# Altium schematic sheet sensie_v_0_0.SchDoc(sensie_v_0_0)
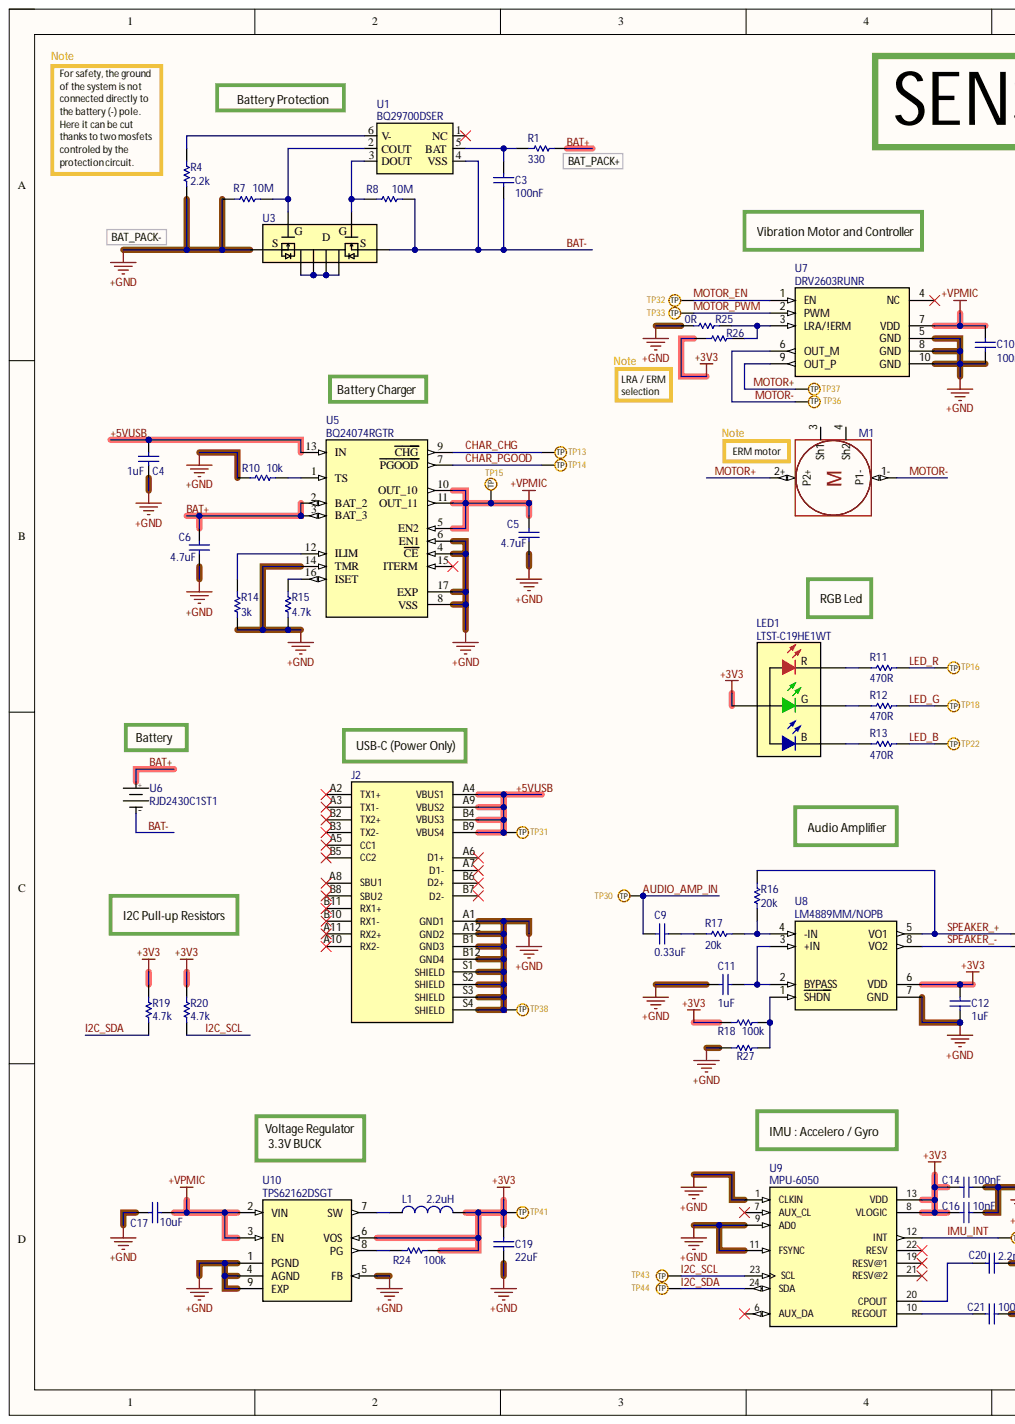
[diagram p0: sensie_v_0_0.SchDoc, left]
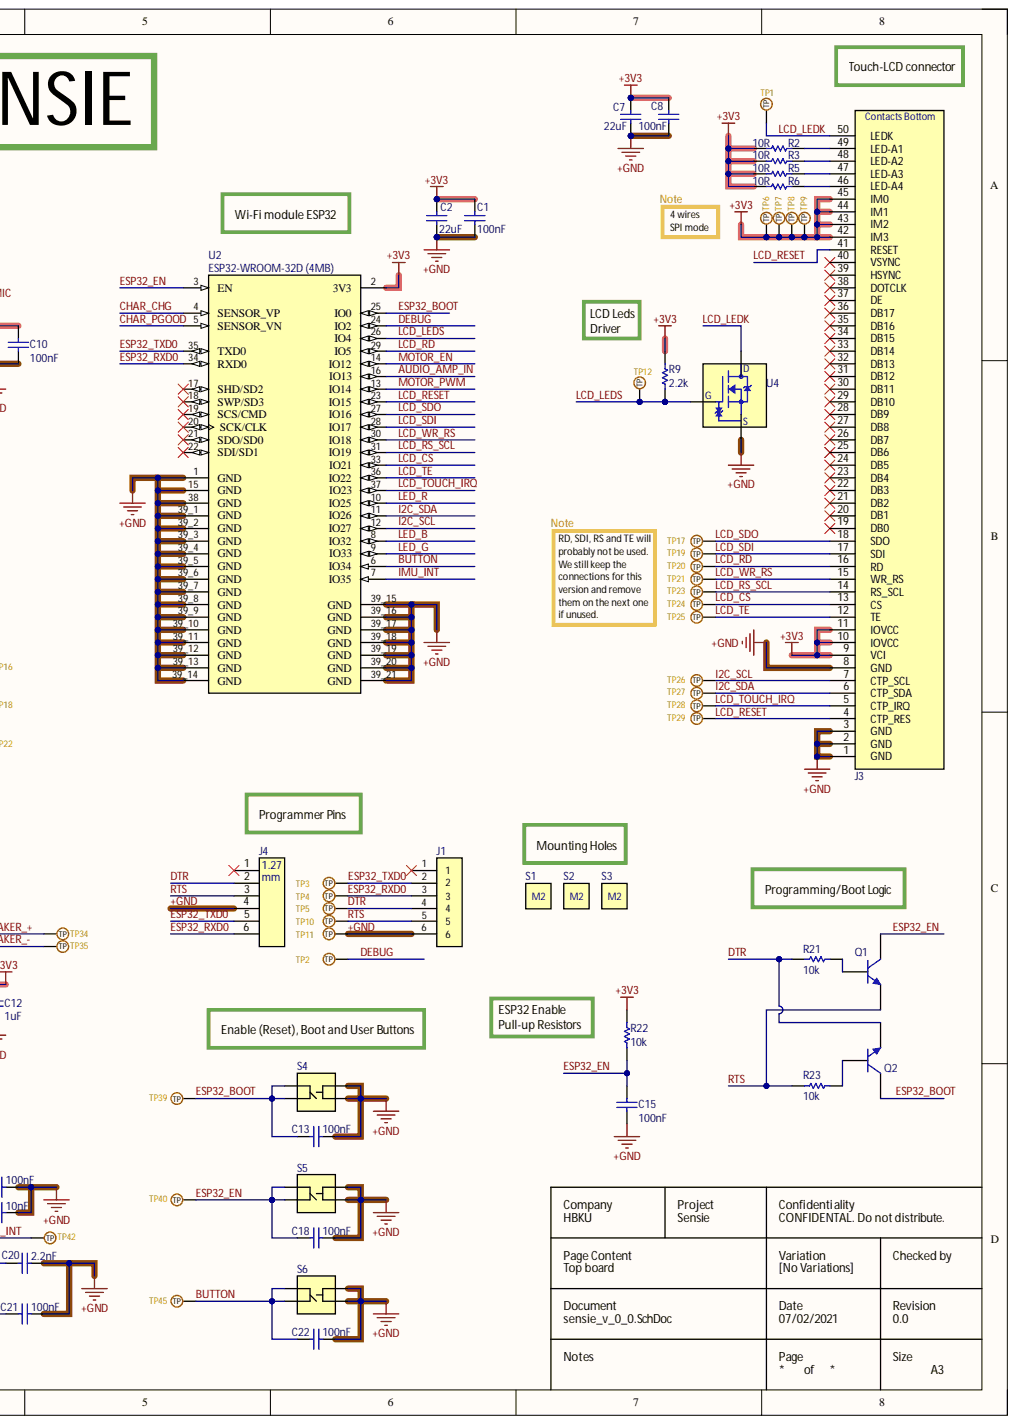
[diagram p1: sensie_v_0_0.SchDoc, right]

## Parts
C1  value 100nF  [C0402]  (hidden comment: Multilayer Ceramic Capacitor)
C2  value 22uF  [C0603]  (hidden comment: Multilayer Ceramic Capacitor)
C3  value 100nF  [C0402]  (hidden comment: Multilayer Ceramic Capacitor)
C4  value 1uF  [C0402]  (hidden comment: Multilayer Ceramic Capacitor)
C5  value 4.7uF  [C0402]  (hidden comment: Multilayer Ceramic Capacitor)
C6  value 4.7uF  [C0402]  (hidden comment: Multilayer Ceramic Capacitor)
C7  value 22uF  [C0603]  (hidden comment: Multilayer Ceramic Capacitor)
C8  value 100nF  [C0402]  (hidden comment: Multilayer Ceramic Capacitor)
C9  value 0.33uF  [C0402]  (hidden comment: Multilayer Ceramic Capacitor)
C10  value 100nF  [C0402]  (hidden comment: Multilayer Ceramic Capacitor)
C11  value 1uF  [C0402]  (hidden comment: Multilayer Ceramic Capacitor)
C12  value 1uF  [C0402]  (hidden comment: Multilayer Ceramic Capacitor)
C13  value 100nF  [C0402]  (hidden comment: Multilayer Ceramic Capacitor)
C14  value 100nF  [C0402]  (hidden comment: Multilayer Ceramic Capacitor)
C15  value 100nF  [C0402]  (hidden comment: Multilayer Ceramic Capacitor)
C16  value 10nF  [C0402]  (hidden comment: Multilayer Ceramic Capacitor)
C17  value 10uF  [C0402]  (hidden comment: Multilayer Ceramic Capacitor)
C18  value 100nF  [C0402]  (hidden comment: Multilayer Ceramic Capacitor)
C19  value 22uF  [C0603]  (hidden comment: Multilayer Ceramic Capacitor)
C20  value 2.2nF  [C0402]  (hidden comment: Multilayer Ceramic Capacitor)
C21  value 100nF  [C0402]  (hidden comment: Multilayer Ceramic Capacitor)
C22  value 100nF  [C0402]  (hidden comment: Multilayer Ceramic Capacitor)
J1  Plug of Nails 6 pins  [PLUG-OF-NAILS-6-PIN]  (value not drawn)
J2  1054500101  [USB-C-1054500101]  (value not drawn)
J3  FPC-50-screen-connector-bottom-62684-501100ALF  [FPC-50-62684-501100ALF]  (value not drawn)
J4  FTS-103-01-F-DV-TR  [CON_6_2_1.27_FTS_103_01]  (value not drawn)
L1  value 2.2uH  [L0603]  (hidden comment: IND 2.2uH 0603)
LED1  Manufacturer Part Number: LTST-C19HE1WT  [LTST-C19HE1WT-4_V]  (hidden comment: rgb_LTST-C19HE1WT)
M1  MOTOR  [MOTOR]
Q1  MMBT2222ALT1G  [ONSC-SOT-23-3-318-08_V]  (value not drawn)
Q2  MMBT2222ALT1G  [ONSC-SOT-23-3-318-08_V]  (value not drawn)
R1  value 330  [R0402]  (hidden comment: Thick Film)
R2  value 10R  [R0402]  (hidden comment: Thick Film)
R3  value 10R  [R0402]  (hidden comment: Thick Film)
R4  value 2.2k  [R0402]  (hidden comment: Thick Film)
R5  value 10R  [R0402]  (hidden comment: Thick Film)
R6  value 10R  [R0402]  (hidden comment: Thick Film)
R7  value 10M  [R0402]  (hidden comment: Thick Film)
R8  value 10M  [R0402]  (hidden comment: Thick Film)
R9  value 2.2k  [R0402]  (hidden comment: Thick Film)
R10  value 10k  [R0402]  (hidden comment: Thick Film)
R11  value 470R  [R0402]  (hidden comment: Thick Film)
R12  value 470R  [R0402]  (hidden comment: Thick Film)
R13  value 470R  [R0402]  (hidden comment: Thick Film)
R14  value 3k  [R0402]  (hidden comment: Thick Film)
R15  value 4.7k  [R0402]  (hidden comment: Thick Film)
R16  value 20k  [R0402]  (hidden comment: Thick Film)
R17  value 20k  [R0402]  (hidden comment: Thick Film)
R18  value 100k  [R0402]  (hidden comment: Thick Film)
R19  value 4.7k  [R0402]  (hidden comment: Thick Film)
R20  value 4.7k  [R0402]  (hidden comment: Thick Film)
R21  value 10k  [R0402]  (hidden comment: Thick Film)
R22  value 10k  [R0402]  (hidden comment: Thick Film)
R23  value 10k  [R0402]  (hidden comment: Thick Film)
R24  value 100k  [R0402]  (hidden comment: Thick Film)
R25  value 0R  [R0402]  (hidden comment: Thick Film)
R26  Thick Film  [R0402_DNI]  (value not drawn)
R27  Thick Film  [R0402_DNI]  (value not drawn)
S1  hole_M2  [M2_no_screw]  (value not drawn)
S2  hole_M2  [M2_no_screw]  (value not drawn)
S3  hole_M2  [M2_no_screw]  (value not drawn)
S4  TL1014BF160QG  [BUTTON_TL1014BF160QG]  (value not drawn)
S5  TL1014BF160QG  [BUTTON_TL1014BF160QG]  (value not drawn)
S6  TL1014BF160QG  [BUTTON_TL1014BF160QG]  (value not drawn)
TP1  TP_1mm  [TP_1mm]  (value not drawn)
TP2  TP_2mm  [TP_2mm]  (value not drawn)
TP3  TP_2mm  [TP_2mm]  (value not drawn)
TP4  TP_2mm  [TP_2mm]  (value not drawn)
TP5  TP_2mm  [TP_2mm]  (value not drawn)
TP6  TP_1mm  [TP_1mm]  (value not drawn)
TP7  TP_1mm  [TP_1mm]  (value not drawn)
TP8  TP_1mm  [TP_1mm]  (value not drawn)
TP9  TP_1mm  [TP_1mm]  (value not drawn)
TP10  TP_2mm  [TP_2mm]  (value not drawn)
TP11  TP_2mm  [TP_2mm]  (value not drawn)
TP12  TP_1mm  [TP_1mm]  (value not drawn)
TP13  TP_1mm  [TP_1mm]  (value not drawn)
TP14  TP_1mm  [TP_1mm]  (value not drawn)
TP15  TP_1mm  [TP_1mm]  (value not drawn)
TP16  TP_1mm  [TP_1mm]  (value not drawn)
TP17  TP_1mm  [TP_1mm]  (value not drawn)
TP18  TP_1mm  [TP_1mm]  (value not drawn)
TP19  TP_1mm  [TP_1mm]  (value not drawn)
TP20  TP_1mm  [TP_1mm]  (value not drawn)
TP21  TP_1mm  [TP_1mm]  (value not drawn)
TP22  TP_1mm  [TP_1mm]  (value not drawn)
TP23  TP_1mm  [TP_1mm]  (value not drawn)
TP24  TP_1mm  [TP_1mm]  (value not drawn)
TP25  TP_1mm  [TP_1mm]  (value not drawn)
TP26  TP_1mm  [TP_1mm]  (value not drawn)
TP27  TP_1mm  [TP_1mm]  (value not drawn)
TP28  TP_1mm  [TP_1mm]  (value not drawn)
TP29  TP_1mm  [TP_1mm]  (value not drawn)
TP30  TP_1mm  [TP_1mm]  (value not drawn)
TP31  TP_1mm  [TP_1mm]  (value not drawn)
TP32  TP_1mm  [TP_1mm]  (value not drawn)
TP33  TP_1mm  [TP_1mm]  (value not drawn)
TP34  TP_2mm  [TP_2mm]  (value not drawn)
TP35  TP_2mm  [TP_2mm]  (value not drawn)
TP36  TP_2mm  [TP_2mm]  (value not drawn)
TP37  TP_2mm  [TP_2mm]  (value not drawn)
TP38  TP_1mm  [TP_1mm]  (value not drawn)
TP39  TP_1mm  [TP_1mm]  (value not drawn)
TP40  TP_1mm  [TP_1mm]  (value not drawn)
TP41  TP_1mm  [TP_1mm]  (value not drawn)
TP42  TP_1mm  [TP_1mm]  (value not drawn)
TP43  TP_1mm  [TP_1mm]  (value not drawn)
TP44  TP_1mm  [TP_1mm]  (value not drawn)
TP45  TP_1mm  [TP_1mm]  (value not drawn)
U1  BQ29700DSER  [BATT_PROTEC_BQ29700DSER]
U2  ESP32-WROOM-32D  [ESP32-WROOM-32D (4MB)]
U3  ECH8693R-TL-W  [MOSFET_2CHAN_ECH8693R-TL-W]  (value not drawn)
U4  DMG1012UW-7  [MOSFET-DMG1012UW-SOT323]  (value not drawn)
U5  BQ24074RGTR  [BATT_CHARG_BQ24074RGTR]
U6  RJD2430C1ST1  [COIN_BAT_110_MAH_RJD2430C1ST1]
U7  DRV2603RUNR  [MOT_DRV_DRV2603RUNR]
U8  LM4889  Manufacturer Part Number: LM4889MM/NOPB  [Amp_LM4889MM]
U9  MPU-6050  [MPU6050-ACCELERO-GYRO]
U10  TPS62162DSGT  [REG_BUCK_TPS62162DSGT]

## Nets
+3V3: C1.2 C2.2 C7.2 C8.2 C12.2 C14.1 C16.1 C19.2 J3.9(VCI) J3.10(IOVCC) J3.11(IOVCC) J3.42(IM3) J3.43(IM2) J3.44(IM1) J3.45(IM0) L1.2 LED1.4 R2.1 R3.1 R5.1 R6.1 R9.2 R18.1 R19.2 R20.2 R22.2 R24.2 R26.1 TP6.1 TP7.1 TP8.1 TP9.1 TP41.1 U2.2(3V3) U8.6(VDD) U9.8(VLOGIC) U9.13(VDD) U10.6(VOS)
+VPMIC: C5.2 C10.2 C17.2 TP15.1 U5.5(EN2) U5.10(OUT_10) U5.11(OUT_11) U7.7(VDD) U10.2(VIN) U10.3(EN)
+5VUSB: C4.2 J2.A4(VBUS1) J2.A9(VBUS2) J2.B4(VBUS3) J2.B9(VBUS4) TP31.1 U5.13(IN)
+GND: C1.1 C2.1 C4.1 C5.1 C6.1 C7.1 C8.1 C10.1 C11.2 C12.1 C13.2 C14.2 C15.1 C16.2 C17.1 C18.2 C19.1 C20.2 C21.2 C22.2 J1.6 J2.A1(GND1) J2.A12(GND2) J2.B1(GND3) J2.B12(GND4) J2.S1(SHIELD) J2.S2(SHIELD) J2.S3(SHIELD) J2.S4(SHIELD) J3.1(GND) J3.2(GND) J3.3(GND) J3.8(GND) J4.4 R4.1 R7.2 R10.2 R14.1 R15.1 R25.1 R27.1 S4.1 S4.3 S5.1 S5.3 S6.1 S6.3 TP11.1 TP38.1 U2.1(GND) U2.15(GND) U2.38(GND) U2.39_1(GND) U2.39_2(GND) U2.39_3(GND) U2.39_4(GND) U2.39_5(GND) U2.39_6(GND) U2.39_7(GND) U2.39_8(GND) U2.39_9(GND) U2.39_10(GND) U2.39_11(GND) U2.39_12(GND) U2.39_13(GND) U2.39_14(GND) U2.39_15(GND) U2.39_16(GND) U2.39_17(GND) U2.39_18(GND) U2.39_19(GND) U2.39_20(GND) U2.39_21(GND) U3.1 U4.3 U5.4(CE) U5.6(EN1) U5.8(VSS) U5.14(TMR) U5.17(EXP) U7.5(GND) U7.8(GND) U7.10(GND) U8.7(GND) U9.1(CLKIN) U9.9(AD0) U9.11(FSYNC) U10.1(PGND) U10.4(AGND) U10.5(FB) U10.9(EXP)
AUDIO_AMP_IN: C9.1 TP30.1 U2.16(IO13)
BAT+: C6.2 R1.2 U5.2(BAT_2) U5.3(BAT_3) U6.1
BAT-: C3.1 R8.1 U1.4(VSS) U3.3 U6.2
BUTTON: C22.1 S6.2 S6.4 TP45.1 U2.6(IO34)
CHAR_CHG: TP13.1 U2.4(SENSOR_VP) U5.9(CHG)
CHAR_PGOOD: TP14.1 U2.5(SENSOR_VN) U5.7(PGOOD)
DEBUG: TP2.1 U2.24(IO2)
DTR: J1.4 J4.2 Q2.2 R21.1 TP5.1
ESP32_BOOT: C13.1 Q2.3 S4.2 S4.4 TP39.1 U2.25(IO0)
ESP32_EN: C15.2 C18.1 Q1.3 R22.1 S5.2 S5.4 TP40.1 U2.3(EN)
ESP32_RXD0: J1.3 J4.6 TP4.1 U2.34(RXD0)
ESP32_TXD0: J1.2 J4.5 TP3.1 U2.35(TXD0)
I2C_SCL: J3.7(CTP_SCL) R20.1 TP26.1 TP43.1 U2.12(IO27) U9.23(SCL)
I2C_SDA: J3.6(CTP_SDA) R19.1 TP27.1 TP44.1 U2.11(IO26) U9.24(SDA)
IMU_INT: TP42.1 U2.7(IO35) U9.12(INT)
LCD_CS: J3.13(CS) TP24.1 U2.33(IO21)
LCD_LEDK: J3.50(LEDK) TP1.1 U4.1
LCD_LEDS: R9.1 TP12.1 U2.26(IO4) U4.2
LCD_RD: J3.16(RD) TP20.1 U2.29(IO5)
LCD_RESET: J3.4(CTP_RES) J3.41(RESET) TP29.1 U2.23(IO15)
LCD_RS_SCL: J3.14(RS_SCL) TP23.1 U2.31(IO19)
LCD_SDI: J3.17(SDI) TP19.1 U2.28(IO17)
LCD_SDO: J3.18(SDO) TP17.1 U2.27(IO16)
LCD_TE: J3.12(TE) TP25.1 U2.36(IO22)
LCD_TOUCH_IRQ: J3.5(CTP_IRQ) TP28.1 U2.37(IO23)
LCD_WR_RS: J3.15(WR_RS) TP21.1 U2.30(IO18)
LED_B: R13.2 TP22.1 U2.8(IO32)
LED_G: R12.2 TP18.1 U2.9(IO33)
LED_R: R11.2 TP16.1 U2.10(IO25)
MOTOR+: M1.2+ TP37.1 U7.9(OUT_P)
MOTOR-: M1.1-(M) TP36.1 U7.6(OUT_M)
MOTOR_EN: TP32.1 U2.14(IO12) U7.1(EN)
MOTOR_PWM: TP33.1 U2.13(IO14) U7.2(PWM)
RTS: J1.5 J4.3 Q1.2 R23.1 TP10.1
SPEAKER_+: R16.2 TP34.1 U8.5(VO1)
SPEAKER_-: TP35.1 U8.8(VO2)
NetC3_2: C3.2 R1.1 U1.5(BAT)
NetC9_2: C9.2 R17.1
NetC11_1: C11.1 U8.2(BYPASS) U8.3(+IN)
NetC20_1: C20.1 U9.20(CPOUT)
NetC21_1: C21.1 U9.10(REGOUT)
NetJ3_46: J3.46(LED-A4) R6.2
NetJ3_47: J3.47(LED-A3) R5.2
NetJ3_48: J3.48(LED-A2) R3.2
NetJ3_49: J3.49(LED-A1) R2.2
NetL1_1: L1.1 U10.7(SW)
NetLED1_1: LED1.1 R11.1
NetLED1_2: LED1.2 R12.1
NetLED1_3: LED1.3 R13.1
NetQ1_1: Q1.1 R21.2
NetQ2_1: Q2.1 R23.2
NetR4_2: R4.2 U1.6(V-)
NetR7_1: R7.1 U1.2(COUT) U3.2
NetR8_2: R8.2 U1.3(DOUT) U3.4
NetR10_1: R10.1 U5.1(TS)
NetR14_2: R14.2 U5.12(ILIM)
NetR15_2: R15.2 U5.16(ISET)
NetR16_1: R16.1 R17.2 U8.4(-IN)
NetR18_2: R18.2 R27.2 U8.1(SHDN)
NetR24_1: R24.1 U10.8(PG)
NetR25_2: R25.2 R26.2 U7.3(LRA/!ERM)
NetU3_5: U3.5 U3.6(D) U3.7 U3.8(G)

Not connected: J1 1; J2 A2(TX1+) A3(TX1-) A5(CC1) A6(D1+) A7(D1-) A8(SBU1) A10(RX2-) A11(RX2+) B2(TX2+) B3(TX2-) B5(CC2) B6(D2+) B7(D2-) B8(SBU2) B10(RX1-) B11(RX1+); J3 19(DB0) 20(DB1) 21(DB2) 22(DB3) 23(DB4) 24(DB5) 25(DB6) 26(DB7) 27(DB8) 28(DB9) 29(DB10) 30(DB11) 31(DB12) 32(DB13) 33(DB14) 34(DB15) 35(DB16) 36(DB17) 37(DE) 38(DOTCLK) 39(HSYNC) 40(VSYNC); J4 1(1.27); M1 3(Sh1) 4(Sh2); U1 1(NC); U2 17(SHD/SD2) 18(SWP/SD3) 19(SCS/CMD) 20(SCK/CLK) 21(SDO/SD0) 22(SDI/SD1); U5 15(ITERM); U7 4(NC); U9 6(AUX_DA) 7(AUX_CL) 19(RESV@1) 21(RESV@2) 22(RESV)

## Library data of the parts
C1 C3 C8 C10 C13-C15 C18 C21 C22 = CAP 100nF 0402: CAP CER 0.1UF 16V X7R 0402; Manufacturer: Murata Electronics; Manufacturer Part Number: GRM155R71C104KA88J; Component Kind: Standard; Library Name: rcl.IntLib; Link: https://www.digikey.com/product-detail/en/murata-electronics/GRM155R71C104KA88J/490-6328-1-ND/3845525; Package: 0402; Supplier: Digikey; Supplier Part Number: 490-6328-1-ND; Voltage Rating: 16V
C2 C7 C19 = CAP 22uF 0603: CAP CER 22UF 6.3V X5R 0603; Manufacturer: Murata Electronics; Manufacturer Part Number: GRM188R60J226MEA0D; Component Kind: Standard; Library Name: rcl.IntLib; Link: https://www.digikey.com/product-detail/en/murata-electronics/GRM188R60J226MEA0D/490-7611-1-ND/4280544; Package: 0603; Supplier: Digikey; Supplier Part Number: 490-7611-1-ND; Voltage Rating: 6.3V
C4 C11 C12 = CAP 1uF 0402: CAP CER 1UF 10V X6S 0402; Manufacturer: Murata Electronics; Manufacturer Part Number: GRM155C81A105KA12D; Component Kind: Standard; Library Name: rcl.IntLib; Link: https://www.digikey.com/product-detail/en/murata-electronics/GRM155C81A105KA12D/490-12699-1-ND/5797668; Package: 0402; Supplier: Digikey; Supplier Part Number: 490-12699-1-ND; Voltage Rating: 10V
C5 C6 = CAP 4.7uF 0402: CAP CER 4.7UF 6.3V X5R 0402; Manufacturer: Murata Electronics; Manufacturer Part Number: GRM155R60J475ME47D; Component Kind: Standard; Library Name: rcl.IntLib; Link: https://www.digikey.com/product-detail/en/murata-electronics/GRM155R60J475ME47D/490-5915-1-ND/3719860; Package: 0402; Supplier: Digikey; Supplier Part Number: 490-5915-1-ND; Voltage Rating: 6.3V
C9 = CAP 0.33uF 0402: CAP CER 0.33UF 10V X6S 0402; Manufacturer: Murata Electronics; Manufacturer Part Number: GRM155R61A334KE15D; Component Kind: Standard; Library Name: rcl.IntLib; Link: https://www.digikey.com/en/products/detail/murata-electronics/GRM155R61A334KE15D/702527; Package: 0402; Supplier: Digikey; Supplier Part Number: 490-3263-1-ND; Voltage Rating: 10V
C16 = CAP 10nF 0402: CAP CER 10nF 16V X7R 0402; Manufacturer: Murata Electronics; Manufacturer Part Number: GCM155R71H103KA55D; Component Kind: Standard; Library Name: rcl.IntLib; Link: https://www.digikey.com/en/products/detail/murata-electronics/GCM155R71H103KA55D/1641636; Package: 0402; Supplier: Digikey; Supplier Part Number: 490-4762-1-ND; Voltage Rating: 16V
C17 = CAP 10uF 0402: CAP CER 10UF 4V X5R 0402; Manufacturer: Murata Electronics; Manufacturer Part Number: GRM155R60G106ME44D; Component Kind: Standard; Library Name: rcl.IntLib; Link: https://www.digikey.com/product-detail/en/murata-electronics/GRM155R60G106ME44D/490-10693-1-ND/5251412; Package: 0402; Supplier: Digikey; Supplier Part Number: 490-10693-1-ND; Voltage Rating: 4V
C20 = CAP 2.2nF 0402: CAP CER 2.2nF 16V X7R 0402; Manufacturer: Murata Electronics; Manufacturer Part Number: GCM155R72A222KA37D; Component Kind: Standard; Library Name: rcl.IntLib; Link: https://www.digikey.com/en/products/detail/murata-electronics/GCM155R72A222KA37D/1765159; Package: 0402; Supplier: Digikey; Supplier Part Number: 490-4911-1-ND; Voltage Rating: 16V
J1 = Plug of Nails 6 pins: CBL PLUG-OF-NAILS 6-PIN with NO ATTACHMENT holes (contrary to the digikey link); Manufacturer: Tag-Connect LLC; Manufacturer Part Number: TC2030-CTX; Component Kind: Standard; Library Name: connectors.IntLib; Link: https://www.digikey.co.uk/product-detail/en/tag-connect-llc/TC2030-CTX/TC2030-CTX-ND/5023324; Price 1 Unit USD: 0; Price 1000+ Units USD: 0; Supplier: Digikey; Supplier Part Number: TC2030-CTX-ND
J2 = 1054500101: USB-C CONN RCP USB3.1 TYPEC 24P SMD RA; Manufacturer: Molex; zz Current Rating Per Pin: 0.25A, 1A, 5A; Component Kind: Standard; Library Name: connectors.IntLib; Link: https://www.digikey.com/en/products/detail/molex/1054500101/5843890; Manufacturer Part Number: 1054500101; Price 1 Unit USD: 1.10; Price 1000+ Units USD: 2.19; Supplier: Digikey; Supplier Part Number: WM12856CT-ND
J3 = FPC-50-screen-connector-bottom-62684-501100ALF: FPC CONN BOTTOM 50POS 0.50MM R/A; Manufacturer: Amphenol ICC (FCI); zz Current Rating Per Pin: 0.5A; Component Kind: Standard; Library Name: connectors.IntLib; Link: https://www.digikey.com/en/products/detail/amphenol-icc-fci/62684-501100ALF/1001511; Manufacturer Part Number: 62684-501100ALF; Price 1 Unit USD: 0.88; Price 1000+ Units USD: 0.48; Supplier: Digikey; Supplier Part Number: 609-1197-1-ND
J4 = FTS-103-01-F-DV-TR: CONN HEADER SMD 6POS 1.27MM; Manufacturer: Samtec Inc.; zz Current Rating Per Pin: 3.4A; Component Kind: Standard; Library Name: connectors.IntLib; Link: https://www.digikey.com/en/products/detail/samtec-inc/FTS-103-01-F-DV-TR/2649936; Manufacturer Part Number: FTS-103-01-F-DV-TR; Price 1 Unit USD: 1.39; Price 1000+ Units USD: 0.78; Supplier: Digikey; Supplier Part Number: SAM14930CT-ND
L1 = IND 2.2uH 0603: 2.2UH20% 1.05A(MAX) / 1.15A(TYP.; Manufacturer: Murata Electronics; Manufacturer Part Number: LQM18PN2R2MGHD; Tolerance: 20%; Component Kind: Standard; Library Name: rcl.IntLib; Link: https://www.digikey.co.uk/product-detail/en/murata-electronics/LQM18PN2R2MGHD/490-14478-6-ND/6607082; Package: 0603; Supplier: Digikey; Supplier Part Number: 490-14478-6-ND
LED1 = rgb_LTST-C19HE1WT: LED RGB DIFFUSED CHIP SMD  LED Tri-Color Blue/Green/Red, 5 V, -20 to 80 degC, 4-Pin SMD, RoHS, Tape and Reel; Manufacturer: Lite-On Inc.; zz Package: 0606; zz Power Supply Rating: 2.1V Red, 3.35V Green, 3.35V Blue; Component Kind: Standard; Library Name: chips.IntLib; Link: https://www.digikey.com/product-detail/en/lite-on-inc/LTST-C19HE1WT/160-2162-1-ND/4866310; Price 1 Unit USD: 0.56; Price 1000+ Units USD: 0.13; Supplier: Digikey; Supplier Part Number: 160-2162-1-ND
M1 = MOTOR: VIBRATION MOTOR CYL 3V SMD; Manufacturer: Jinlong Machinery & Electronics, Inc.; Manufacturer Part Number: Z4FC1B1301781; Component Kind: Standard; Link: https://www.digikey.com/product-detail/en/jinlong-machinery-electronics-inc/Z4FC1B1301781/1670-1011-1-ND/6009936; Price 1 Unit USD: 3.49; Price 1000+ Units USD: 2.08; Supplier: Digikey; Supplier Part Number: 1670-1011-1-ND; Library Name: miscellaneaous.IntLib
Q1 Q2 = MMBT2222ALT1G: TRANS NPN 40V 0.6A SOT23   General Purpose Transistor, NPN Silicon, 3-Pin SOT-23, Pb-Free, Tape and Reel; Manufacturer: ON Semiconductor; Component Kind: Standard; ComponentLink1Description: Manufacturer URL; ComponentLink1URL: http://www.onsemi.com/; ComponentLink2Description: Datasheet; ComponentLink2URL: http://www.onsemi.cn/pub_link/Collateral/MMBT2222LT1-D.PDF; ComponentLink3Description: Package Specification; ComponentLink3URL: http://www.onsemi.cn/pub_link/Collateral/318-08.PDF; Library Name: chips.IntLib; Link: https://www.digikey.co.uk/product-detail/en/on-semiconductor/MMBT2222ALT1G/MMBT2222ALT1GOSCT-ND/1139806; Manufacturer Part Number: MMBT2222ALT1G; Price 1 Unit USD: 0.15; Price 1000+ Units USD: 0.02; Supplier: Digikey; Supplier Part Number: MMBT2222ALT1GOSCT-ND
R1 = RES 330R 0402: RES SMD 330 OHM 1% 1/16W 0402; Manufacturer: Yageo; Manufacturer Part Number: RC0402FR-07330RL; Component Kind: Standard; Library Name: rcl.IntLib; Link: https://www.digikey.co.uk/product-detail/en/yageo/RC0402FR-07330RL/311-330LRCT-ND/729541; Package: 0402; Power: 1/16W; Supplier: Digikey; Supplier Part Number: 311-330LRCT-ND; Tolerance: 1%
R2 R3 R5 R6 = RES 10R 0402: RES SMD 10 OHM 1% 1/16W 0402; Manufacturer: Yageo; Manufacturer Part Number: RC0402FR-0710RL; Component Kind: Standard; Library Name: rcl.IntLib; Link: https://www.digikey.co.uk/product-detail/en/yageo/RC0402FR-0710RL/311-10-0LRCT-ND/729471; Package: 0402; Power: 1/16W; Supplier: Digikey; Supplier Part Number: 311-10.0LRCT-ND; Tolerance: 1%
R4 R9 = RES 2.2k 0402: RES SMD 2.2K OHM 1% 1/16W 0402; Manufacturer: Yageo; Manufacturer Part Number: RC0402FR-072K2L; Component Kind: Standard; Library Name: rcl.IntLib; Link: https://www.digikey.co.uk/product-detail/en/yageo/RC0402FR-072K2L/311-2-20KLRCT-ND/729500; Package: 0402; Power: 1/16W; Supplier: Digikey; Supplier Part Number: 311-2.20KLRCT-ND; Tolerance: 1%
R7 R8 = RES 10M 0402: RES SMD 10 MOHM 1% 1/16W 0402; Manufacturer: Yageo; Manufacturer Part Number: RC0402FR-0710ML; Component Kind: Standard; Library Name: rcl.IntLib; Link: https://www.digikey.co.uk/product-detail/en/yageo/RC0402FR-0710ML/311-10-0MLRCT-ND/2827890; Package: 0402; Power: 1/16W; Supplier: Digikey; Supplier Part Number: 311-10.0MLRCT-ND; Tolerance: 1%
R10 R21-R23 = RES 10k 0402: RES SMD 10K OHM 1% 1/16W 0402; Manufacturer: Yageo; Manufacturer Part Number: RC0402FR-0710KL; Component Kind: Standard; Library Name: rcl.IntLib; Link: https://www.digikey.com/product-detail/en/yageo/RC0402FR-0710KL/311-10-0KLRCT-ND/729470; Package: 0402; Power: 1/16W; Supplier: Digikey; Supplier Part Number: 311-10.0KLRCT-ND; Tolerance: 1%
R11-R13 = RES 470R 0402: RES SMD 470 OHM 1% 1/16W 0402; Manufacturer: Yageo; Manufacturer Part Number: RC0402FR-07470RL; Component Kind: Standard; Library Name: rcl.IntLib; Link: https://www.digikey.com/product-detail/en/yageo/RC0402FR-07470RL/311-470LRCT-ND/729566; Package: 0402; Power: 1/16W; Supplier: Digikey; Supplier Part Number: 311-470LRCT-ND; Tolerance: 1%
R14 = RES 3k 0402: RES SMD 10K OHM 1% 1/16W 0402; Manufacturer: Yageo; Manufacturer Part Number: RC0402FR-073KL; Component Kind: Standard; Library Name: rcl.IntLib; Link: https://www.digikey.co.uk/product-detail/en/yageo/RC0402FR-073KL/311-3KLRCT-ND/2827904; Package: 0402; Power: 1/16W; Supplier: Digikey; Supplier Part Number: 311-3KLRCT-ND; Tolerance: 1%
R15 R19 R20 = RES 4.7k 0402: RES SMD 4.7K OHM 1% 1/16W 0402; Manufacturer: Yageo; Manufacturer Part Number: RT0402FRE074K7L; Component Kind: Standard; Library Name: rcl.IntLib; Link: https://www.digikey.co.uk/product-detail/en/yageo/RT0402FRE074K7L/YAG3347CT-ND/5418052; Power: 1/16W; Supplier: Digikey; Supplier Part Number: YAG3347CT-ND; Tolerance: 1%
R16 R17 = RES 20k 0402: RES SMD 20K OHM 1% 1/16W 0402; Manufacturer: Yageo; Manufacturer Part Number: RC0402FR-0720KL; Component Kind: Standard; Library Name: rcl.IntLib; Link: https://www.digikey.co.uk/product-detail/en/yageo/RC0402FR-0720KL/311-20-0KLRCT-ND/729504; Power: 1/16W; Supplier: Digikey; Supplier Part Number: 311-20.0KLRCT-ND; Tolerance: 1%
R18 R24 = RES 100k 0402: RES SMD 100K OHM 1% 1/16W 0402; Manufacturer: Yageo; Manufacturer Part Number: RC0402FR-07100KL; Component Kind: Standard; Library Name: rcl.IntLib; Link: https://www.digikey.co.uk/product-detail/en/yageo/RC0402FR-07100KL/311-100KLRCT-ND/729473; Power: 1/16W; Supplier: Digikey; Supplier Part Number: 311-100KLRCT-ND; Tolerance: 1%
R25 = RES 0R 0402: RES SMD 0 OHM JUMPER 1/16W 0402; Manufacturer: Yageo; Manufacturer Part Number: RC0402JR-070RL; Tolerance: 1%; Component Kind: Standard; Library Name: rcl.IntLib; Link: https://www.digikey.com/product-detail/en/yageo/RC0402JR-070RL/311-0-0JRCT-ND/729353; Package: 0402; Power: 1/16W; Supplier: Digikey; Supplier Part Number: 311-0.0JRCT-ND
R26 R27 = RES DNI 0402: DNI (Do Not Install); Component Kind: Standard; Library Name: rcl.IntLib
S1-S3 = hole_M2: Mounting hole  M2 size, no solder stop, so no screw; Component Kind: Standard; Library Name: connectors.IntLib
S4-S6 = TL1014BF160QG: SWITCH TACTILE SPST-NO 0.05A 12V; Manufacturer: E-Switch; Component Kind: Standard; ComponentLink1Description: Manufacturer URL; ComponentLink2Description: Datasheet; ComponentLink2URL: http://spec_sheets.e-switch.com/specs/P410002.pdf; Link: https://www.digikey.com/product-detail/en/e-switch/TL1014BF160QG/EG5042CT-ND/3910808; Manufacturer Part Number: TL1014BF160QG; Price 1 Unit USD: 0.65; Price 1000+ Units USD: 0.40; Supplier: Digikey; Supplier Part Number: EG5042CT-ND; Library Name: miscellaneaous.IntLib
TP1 TP6-TP9 TP12-TP33 TP38-TP45 = TP_1mm: Test point 1mm diameter; Component Kind: Standard; ComponentLink1Description: Manufacturer URL; ComponentLink2Description: Datasheet; Supplier: Digikey; Library Name: miscellaneaous.IntLib
TP2-TP5 TP10 TP11 TP34-TP37 = TP_2mm: Test point 2mm diameter; Component Kind: Standard; ComponentLink1Description: Manufacturer URL; ComponentLink2Description: Datasheet; Supplier: Digikey; Library Name: miscellaneaous.IntLib
U1 = BQ29700DSER: BATT LITHIUM BATT PROTECT 6-SON; Manufacturer: Texas Instruments; Component Kind: Standard; ComponentLink1Description: Manufacturer URL; ComponentLink2Description: Datasheet; Library Name: chips.IntLib; Link: https://www.digikey.com/product-detail/en/texas-instruments/BQ29700DSER/296-43985-1-ND/5973209; Manufacturer Part Number: BQ29700DSER; Price 1 Unit USD: 0.56; Price 1000+ Units USD: 0.21; Supplier: Digikey; Supplier Part Number: 296-43985-1-ND
U2 = ESP32-WROOM-32D: ESP32-WROOM-32D Wifi/Bluetooth Module; Manufacturer: Espressif Systems; Component Kind: Standard; ComponentLink1Description: Manufacturer URL; ComponentLink2Description: Datasheet; Library Name: chips.IntLib; Link: https://www.digikey.com/en/products/detail/espressif-systems/ESP32-WROOM-32D-4MB/9381716; Manufacturer Part Number: ESP32-WROOM-32D (4MB); Price 1 Unit USD: 2.4; Price 1000+ Units USD: 3.8; Supplier: Digikey; Supplier Part Number: 1965-ESP32-WROOM-32D(4MB)CT-ND
U3 = ECH8693R-TL-W: MOSFET 2N-CH 24V 14A SOT-28; Manufacturer: ON Semiconductor; Component Kind: Standard; ComponentLink1Description: Manufacturer URL; ComponentLink2Description: Datasheet; Library Name: chips.IntLib; Link: https://www.digikey.com/product-detail/en/on-semiconductor/ECH8693R-TL-W/ECH8693R-TL-WOSCT-ND/5969317; Manufacturer Part Number: ECH8693R-TL-W; Price 1 Unit USD: 0.20; Price 1000+ Units USD: 0.53; Supplier: Digikey; Supplier Part Number: ECH8693R-TL-WOSCT-ND
U4 = DMG1012UW-7: MOSFET Logi level N-CH 20V 1A SOT323; Manufacturer: Diodes Incorporated; Component Kind: Standard; ComponentLink1Description: Manufacturer URL; ComponentLink2Description: Datasheet; Library Name: chips.IntLib; Link: https://www.digikey.com/en/products/detail/diodes-incorporated/DMG1012UW-7/2183239; Manufacturer Part Number: DMG1012UW-7; Price 1 Unit USD: 0.33; Price 1000+ Units USD: 0.05; Supplier: Digikey; Supplier Part Number: DMG1012UW-7DICT-ND
U5 = BQ24074RGTR: BATT LI-ION CHARGE MGMT 16-QFN; Manufacturer: Texas Instruments; Component Kind: Standard; ComponentLink1Description: Manufacturer URL; ComponentLink2Description: Datasheet; Library Name: chips.IntLib; Link: https://www.digikey.com/product-detail/en/texas-instruments/BQ24074RGTR/296-38873-1-ND/5142985; Manufacturer Part Number: BQ24074RGTR; Price 1 Unit USD: 2.21; Price 1000+ Units USD: 1.01; Supplier: Digikey; Supplier Part Number: 296-38873-1-ND
U6 = RJD2430C1ST1: BATTERY LITHIUM 110mAh 3.7V COIN 24.5MM; Manufacturer: Illinois Capacitor; Component Kind: Standard; ComponentLink1Description: Manufacturer URL; ComponentLink2Description: Datasheet; Link: https://www.digikey.com/en/products/detail/illinois-capacitor/RJD2430C1ST1/6596418?s=N4IgTCBcDaIEoCkAiYAsBmADAYQIwGUAVXEAXQF8g; Manufacturer Part Number: RJD2430C1ST1; Price 1 Unit USD: 11.00; Price 1000+ Units USD: 7.00; Supplier: Digikey; Supplier Part Number: 1572-1630-ND; Library Name: miscellaneaous.IntLib
U7 = DRV2603RUNR: MOTOR DRIVER 2.5V-5.2V 10QFN; Manufacturer: Texas Instruments; Component Kind: Standard; ComponentLink1Description: Manufacturer URL; ComponentLink2Description: Datasheet; Library Name: chips.IntLib; Link: https://www.digikey.com/product-detail/en/texas-instruments/DRV2603RUNR/296-30613-1-ND/3487222; Manufacturer Part Number: DRV2603RUNR; Price 1 Unit USD: 1.31; Price 1000+ Units USD: 0.55; Supplier: Digikey; Supplier Part Number: 296-30613-1-ND
U8 = LM4889: IC AMP CLASS AB MONO 1W 8VSSOP; Manufacturer: Texas Instruments; Component Kind: Standard; ComponentLink1Description: Manufacturer URL; ComponentLink2Description: Datasheet; Library Name: chips.IntLib; Link: https://www.digikey.com/en/products/detail/texas-instruments/LM4889MM-NOPB/947616; Price 1 Unit USD: 1.31; Price 1000+ Units USD: 0.71; Supplier: Digikey; Supplier Part Number: LM4889MM/NOPBCT-ND
U9 = MPU-6050: IMU ACCEL/GYRO 3-AXIS I2C 24QFN; Manufacturer: TDK InvenSense; Component Kind: Standard; ComponentLink1Description: Manufacturer URL; ComponentLink2Description: Datasheet; Library Name: chips.IntLib; Link: https://www.digikey.com/en/products/detail/tdk-invensense/MPU-6050/4038009; Manufacturer Part Number: MPU-6050; Price 1 Unit USD: 7.55; Price 1000+ Units USD: 3.70; Supplier: Digikey; Supplier Part Number: 1428-1007-1-ND
U10 = TPS62162DSGT: IC REG BUCK 3.3V 1A 8WSON; Manufacturer: Texas Instruments; Component Kind: Standard; ComponentLink1Description: Manufacturer URL; ComponentLink2Description: Datasheet; Library Name: chips.IntLib; Link: https://www.digikey.co.uk/product-detail/en/texas-instruments/TPS62162DSGT/296-29897-1-ND/2782627; Manufacturer Part Number: TPS62162DSGT; Price 1 Unit USD: 1.40; Price 1000+ Units USD: 0.53; Supplier: Digikey; Supplier Part Number: 296-29897-1-ND
